# Altium schematic sheet LPEDT_revA1_bikeit_MCU_IO.SchDoc(LPEDT_revA1_bikeit_MCU_IO)
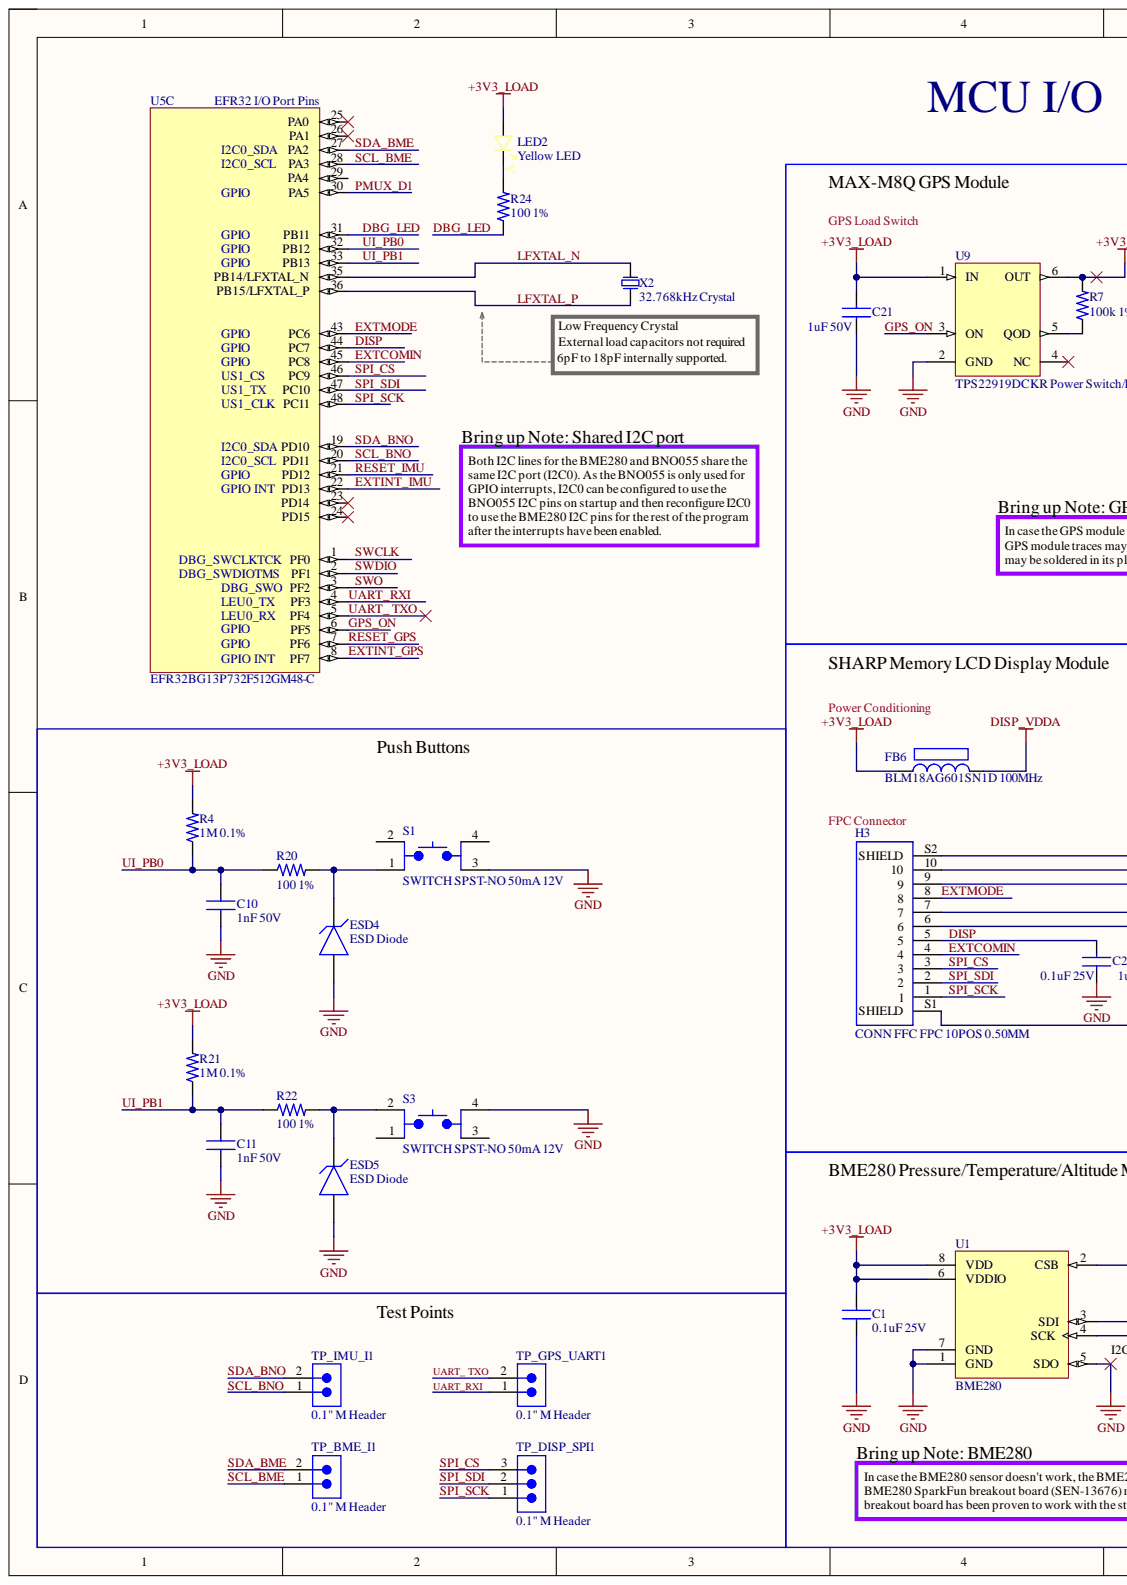
[diagram p0: LPEDT_revA1_bikeit_MCU_IO.SchDoc, left]
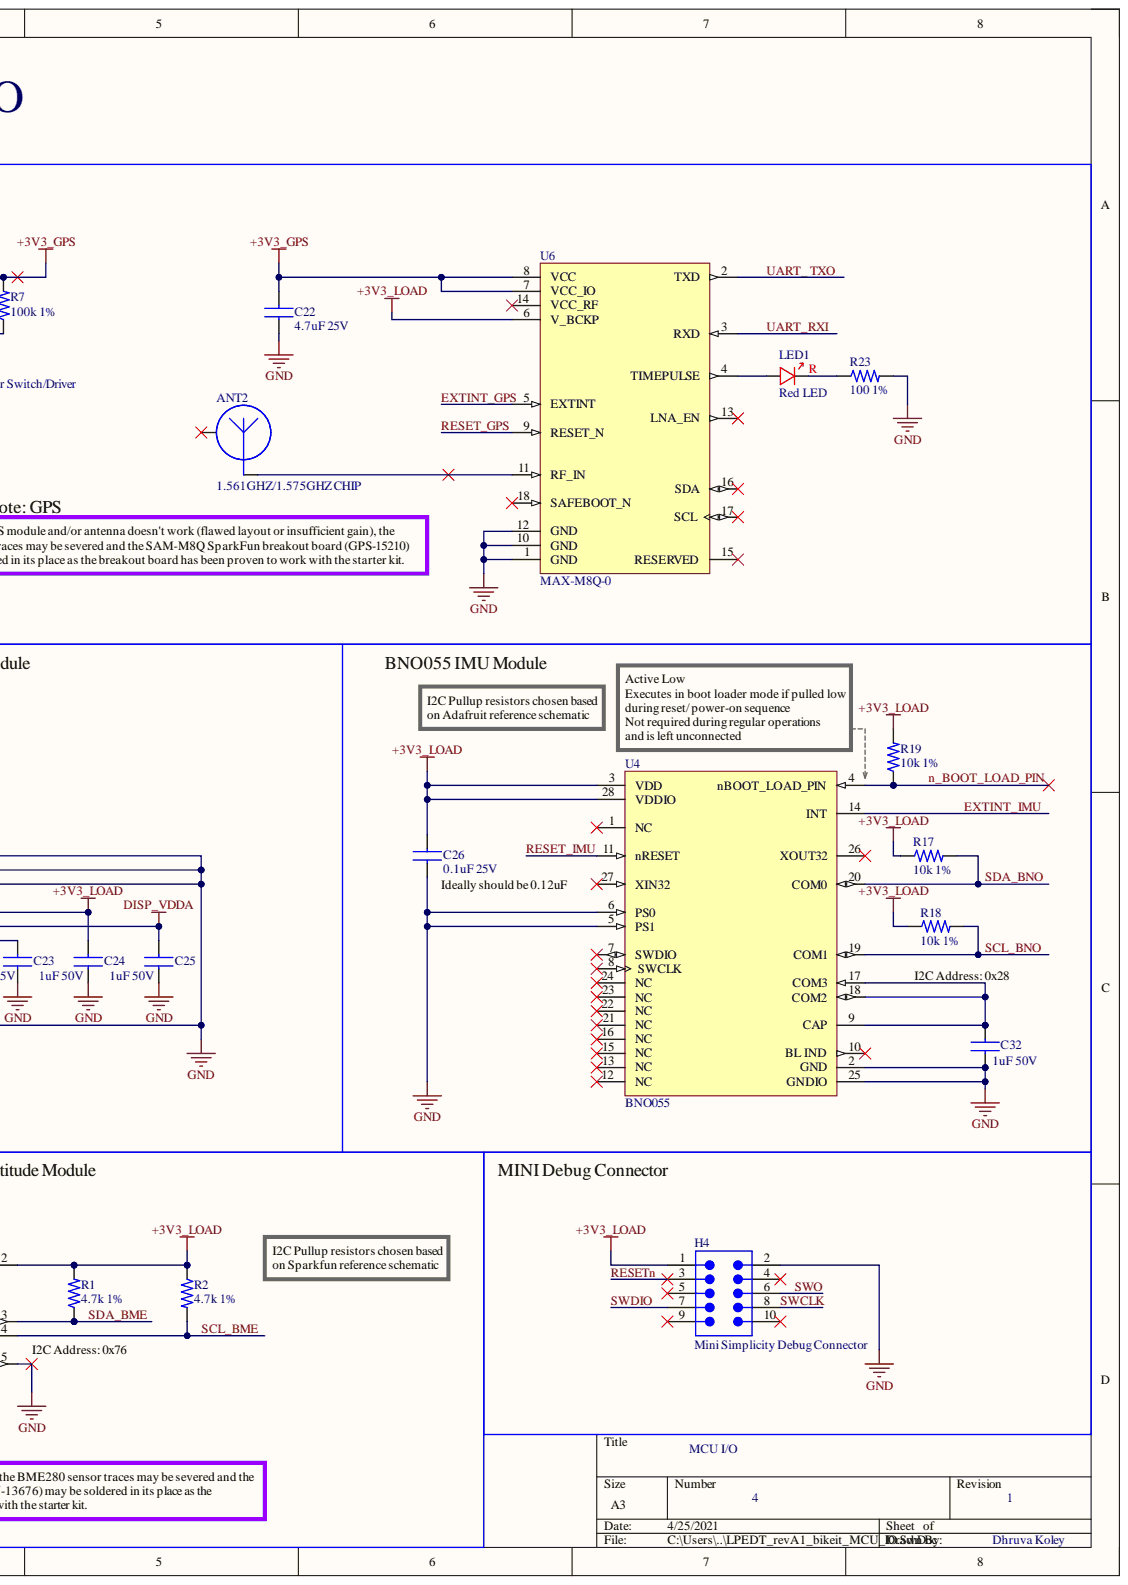
[diagram p1: LPEDT_revA1_bikeit_MCU_IO.SchDoc, right]

## Parts
ANT2  1.561GHZ/1.575GHZ CHIP  [CHIP_ANTENNA]
C1  0.1uF 25V  [CAP_0603_1.60mm*0.80mm]
C10  1nF 50V  Capacitance: 1nF  Voltage: 50V  [CAP_0603_1.60mm*0.80mm]
C11  1nF 50V  Capacitance: 1nF  Voltage: 50V  [CAP_0603_1.60mm*0.80mm]
C21  1uF 50V  Capacitance: 1uF  Voltage Rating: 50V  [CAP_0603_1.60mm*0.80mm]
C22  4.7uF 25V  Capacitance: 4.7uF  [CAP_0603_1.60mm*0.80mm]
C23  0.1uF 25V  Voltage Rating: 25V  [CAP_0603_1.60mm*0.80mm]
C24  1uF 50V  Capacitance: 1uF  Voltage Rating: 50V  [CAP_0603_1.60mm*0.80mm]
C25  1uF 50V  Voltage Rating: 50V  [CAP_0603_1.60mm*0.80mm]
C26  0.1uF 25V  [CAP_0603_1.60mm*0.80mm]
C32  1uF 50V  Capacitance: 1uF  Voltage Rating: 50V  [CAP_0603_1.60mm*0.80mm]
ESD4  ESD Diode  [ESD_SOD882]
ESD5  ESD Diode  [ESD_SOD882]
FB6  BLM18AG601SN1D 100MHz  Frequency: 100MHz  [FB_Chip2PinSM127P600-8N]
H3  CONN FFC FPC 10POS 0.50MM  [FPC_AYF531035A]
H4  Mini Simplicity Debug Connector  [FTSH-105-01-XXX-DV-K]
LED1  Red LED  Color: Red  [LED_0603_RED_WL-SMCW]
LED2  Yellow LED  Color: Yellow  [LED_0603_YLW_WL-SMCW]
R1  4.7k 1%  Resistance Tolerance: 1%  [RES_0603_1608X06]
R2  4.7k 1%  Resistance Tolerance: 1%  [RES_0603_1608X06]
R4  1M 0.1%  Resistance Tolerance: 0.1%  [RES_0603_1608X06]
R7  100k 1%  Resistance Tolerance: 1%  [RES_0603_1608X06]
R17  10k 1%  Resistance Tolerance: 1%  [RES_0603_1608X06]
R18  10k 1%  Resistance Tolerance: 1%  [RES_0603_1608X06]
R19  10k 1%  Resistance Tolerance: 1%  [RES_0603_1608X06]
R20  100 1%  Tolerance: 1%  [RES_0603_1608X06]
R21  1M 0.1%  Resistance Tolerance: 0.1%  [RES_0603_1608X06]
R22  100 1%  Tolerance: 1%  [RES_0603_1608X06]
R23  100 1%  Tolerance: 1%  [RES_0603_1608X06]
R24  100 1%  Tolerance: 1%  [RES_0603_1608X06]
S1  SWITCH SPST-NO 50mA 12V  [SW_TL3305AF260QG]
S3  SWITCH SPST-NO 50mA 12V  [SW_TL3305AF260QG]
TP_BME_I1  0.1" M Header  [2-PIN_MALE_NO 3D]
TP_DISP_SPI1  0.1" M Header  [3-PIN_MALE_NO 3D]
TP_GPS_UART1  0.1" M Header  [2-PIN_MALE_NO 3D]
TP_IMU_I1  0.1" M Header  [2-PIN_MALE_NO 3D]
U1  BME280  [BME280_PSON65P250X250X100-8N]
U4  BNO055  [BNO055-MFG]
U5  EFR32BG13P732F512GM48-C  [MCU_QFN50P700X700X90_HS-49N]  (part C)
U6  MAX-M8Q-0  [XCVR_MAX-M8C-0-10]
U9  TPS22919DCKR Power Switch/Driver  [LS_SOT65P210X110-6N]
X2  32.768kHz Crystal  [SMD_2 Crystal]

## Nets
+3V3_GPS: C22.1 R7.2 U6.7(VCC_IO) U6.8(VCC) U9.6(OUT)
+3V3_LOAD: C1.1 C21.1 C24.1 C26.1 FB6.1 H3.7 H4.1 LED2.A R1.2 R2.1 R4.2 R17.1 R18.1 R19.2 R21.2 U1.2(CSB) U1.6(VDDIO) U1.8(VDD) U4.3(VDD) U4.28(VDDIO) U6.6(V_BCKP) U9.1(IN)
DISP_VDDA: C25.1 FB6.2 H3.6
GND: C1.2 C10.2 C11.2 C21.2 C22.2 C23.2 C24.2 C25.2 C26.2 C32.2 ESD4.2 ESD5.2 H3.9 H3.10 H3.S1(SHIELD) H3.S2(SHIELD) H4.2 R23.2 S1.3 S3.4 U1.1(GND) U1.5(SDO) U1.7(GND) U4.2(GND) U4.5(PS1) U4.6(PS0) U4.25(GNDIO) U6.1(GND) U6.10(GND) U6.12(GND) U9.2(GND)
DBG_LED: R24.1 U5.31(PB11)
DISP: C23.1 H3.5 U5.44(PC7)
EXTCOMIN: H3.4 U5.45(PC8)
EXTINT_GPS: U5.8(PF7) U6.5(EXTINT)
EXTINT_IMU: U4.14(INT) U5.22(PD13)
EXTMODE: H3.8 U5.43(PC6)
GPS_ON: U5.6(PF5) U9.3(ON)
LFXTAL_N: U5.35(PB14/LFXTAL_N) X2.2
LFXTAL_P: U5.36(PB15/LFXTAL_P) X2.1
n_BOOT_LOAD_PIN: R19.1 U4.4(nBOOT_LOAD_PIN)
PMUX_D1: U5.30(PA5)
RESET_GPS: U5.7(PF6) U6.9(RESET_N)
RESET_IMU: U4.11(nRESET) U5.21(PD12)
RESETn: H4.3
SCL_BME: R2.2 TP_BME_I1.1 U1.4(SCK) U5.28(PA3)
SCL_BNO: R18.2 TP_IMU_I1.1 U4.19(COM1) U5.20(PD11)
SDA_BME: R1.1 TP_BME_I1.2 U1.3(SDI) U5.27(PA2)
SDA_BNO: R17.2 TP_IMU_I1.2 U4.20(COM0) U5.19(I2C0_SDA_PD10)
SPI_CS: H3.3 TP_DISP_SPI1.3 U5.46(PC9)
SPI_SCK: H3.1 TP_DISP_SPI1.1 U5.48(PC11)
SPI_SDI: H3.2 TP_DISP_SPI1.2 U5.47(PC10)
SWCLK: H4.8 U5.1(PF0)
SWDIO: H4.7 U5.2(PF1)
SWO: H4.6 U5.3(PF2)
UART_ TXO: TP_GPS_UART1.2 U5.5(PF4) U6.2(TXD)
UART_RXI: TP_GPS_UART1.1 U5.4(PF3) U6.3(RXD)
UI_PB0: C10.1 R4.1 R20.1 U5.32(PB12)
UI_PB1: C11.1 R21.1 R22.1 U5.33(PB13)
NetANT2_1: ANT2.1 U6.11(RF_IN)
NetC32_1: C32.1 U4.9(CAP) U4.17(COM3) U4.18(COM2)
NetESD4_1: ESD4.1 R20.2 S1.1
NetESD5_1: ESD5.1 R22.2 S3.2
NetLED1_A: LED1.A U6.4(TIMEPULSE)
NetLED1_C: LED1.C R23.1
NetLED2_C: LED2.C R24.2
NetR7_1: R7.1 U9.5(QOD)

Not connected: ANT2 2; H4 4 5 9 10; S1 2 4; S3 1 3; U4 1(NC) 7(SWDIO) 8(SWCLK) 10(BL_IND) 12(NC) 13(NC) 15(NC) 16(NC) 21(NC) 22(NC) 23(NC) 24(NC) 26(XOUT32) 27(XIN32); U5 23(PD14) 24(PD15) 25(PA0) 26(PA1) 29(PA4); U6 13(LNA_EN) 14(VCC_RF) 15(RESERVED) 16(SDA) 17(SCL) 18(SAFEBOOT_N); U9 4(NC)

## Library data of the parts
ANT2 = 1575AT43A0040E: RF ANT 1.561GHZ/1.575GHZ CHIP; Manufacturer: Johanson; Bandwidth: 40MHz; Gain: -2.5dB; Impedance: 50R; Max Power Dissipation: 3W; Number of Bands: 1; Return Loss: -9.5dB; Case/Package: SMD/SMT; Category: RF Antennas; Component Kind: Standard; ComponentLink1Description: https://datasheet.ciiva.com/2792/jti-antenna-1575at43a40-2006-09-2792227.pdf?src-supplier=Digi-Key; ComponentLink1URL: https://datasheet.ciiva.com/2792/jti-antenna-1575at43a40-2006-09-2792227.pdf?src-supplier=Digi-Key; ComponentLink2Description: https://datasheet.ciiva.com/24048/antenna-1575at43a0040-258086-24048619.pdf?src-supplier=Mouser; ComponentLink2URL: https://datasheet.ciiva.com/24048/antenna-1575at43a0040-258086-24048619.pdf?src-supplier=Mouser; ComponentLink3Description: https://datasheet.ciiva.com/4172/antenna-1575at43a0040-4172807.pdf?src-supplier=Arrow+Electronics; ComponentLink3URL: https://datasheet.ciiva.com/4172/antenna-1575at43a0040-4172807.pdf?src-supplier=Arrow+Electronics; Frequency: 1.575GHz; Height: 0.031inch; Lead Free: Lead Free; Length: 7mm; Manufacturer Part Number: 1575AT43A0040E; Max Operating Temperature: 85°C; Min Operating Temperature: -40°C; Mount: Surface Mount; Number of Pins: 2; Package Quantity: 1; Packaging: Tape and Reel; Power Rating: 3W; Pricing 1: 1=0.84000, 10=0.75200, 25=0.71400, 100=0.58650, 250=0.54824, 500=0.48450 (USD); RoHS: Yes; Stock 1: 56; Supplier 1: Digi-Key; Supplier Part Number 1: 712-1003-1-ND; Termination: Solder; Width: 2mm; Library Name: LPEDT_revA1_bikeit_IL.IntLib
C1 C23 C26 = GCJ188R71E104KA12D: CAP CER 0.1UF 25V X7R 0603; Manufacturer: Murata; Capacitance: 100nF; Tolerance: 10%; Case Code (Imperial): 0603; Case Code (Metric): 1608; Case/Package: 0603; Category: Ceramic Capacitors; Component Kind: Standard; ComponentLink1Description: http://search.murata.co.jp/Ceramy/image/img/A01X/G101/ENG/GCJ188R71E104KA12-01.pdf?src-supplier=Digi-Key; ComponentLink1URL: http://search.murata.co.jp/Ceramy/image/img/A01X/G101/ENG/GCJ188R71E104KA12-01.pdf?src-supplier=Digi-Key; Features: Soft Termination; Manufacturer Part Number: GCJ188R71E104KA12D; Max Operating Temperature: 125°C; Min Operating Temperature: -55°C; Mount: Surface Mount; Packaging: Tape and Reel; Pricing 1: 1=0.21000, 10=0.14900, 50=0.08480, 100=0.07030, 500=0.04928, 1000=0.04080 (USD); Ratings: AEC-Q200; RoHS: Yes; RoHS Compliant: Yes; Stock 1: 1672; Supplier 1: Mouser; Supplier Part Number 1: 81-GCJ188R71E104KA2D; Library Name: LPEDT_revA1_bikeit.SchLib
  C1 C26: Voltage Rating: 25V
C10 C11 = CL10B102KB8NNNC: Cap Ceramic 0.001uF 50V X7R 10% Pad SMD 0603 125°C T/R; Manufacturer: Samsung; Case Code (Imperial): 0603; Case Code (Metric): 1608; Case/Package: 0603; Category: Ceramic Capacitors; Component Kind: Standard; ComponentLink1Description: https://datasheet.ciiva.com/25805/cl10b102kb8nnnc-25805137.pdf?src-supplier=Digi-Key; ComponentLink1URL: https://datasheet.ciiva.com/25805/cl10b102kb8nnnc-25805137.pdf?src-supplier=Digi-Key; ComponentLink2Description: https://datasheet.ciiva.com/15216/getdatasheetpartid-359690-15216231.pdf?src-supplier=Verical; ComponentLink2URL: https://datasheet.ciiva.com/15216/getdatasheetpartid-359690-15216231.pdf?src-supplier=Verical; ComponentLink3Description: https://datasheet.ciiva.com/29198/458300-da-01-en-vs-kondensator-0603-29198498.pdf?src-supplier=Conrad; ComponentLink3URL: https://datasheet.ciiva.com/29198/458300-da-01-en-vs-kondensator-0603-29198498.pdf?src-supplier=Conrad; ComponentLink4Description: https://datasheet.ciiva.com/16199/cl05b104kq5nnnc-16199064.pdf?src-supplier=Future+Electronics; ComponentLink4URL: https://datasheet.ciiva.com/16199/cl05b104kq5nnnc-16199064.pdf?src-supplier=Future+Electronics; ComponentLink5Description: https://datasheet.ciiva.com/26310/mlcc-catalogdownload2013-eng-26310326.pdf?src-supplier=Distrelec; ComponentLink5URL: https://datasheet.ciiva.com/26310/mlcc-catalogdownload2013-eng-26310326.pdf?src-supplier=Distrelec; Construction: Flat; Contact Plating: Tin; Depth: 0.8mm; Dielectric: X7R; Height: 0.8mm; Lead Free: Lead Free; Length: 1.6mm; Manufacturer Part Number: CL10B102KB8NNNC; Material: Ceramic; Max Operating Temperature: 125°C; Min Operating Temperature: -55°C; Mount: Surface Mount; Package Quantity: 1; Packaging: Tape and Reel; Pricing 1: 4000=0.00643, 8000=0.00587 (USD); Radiation Hardening: No; RoHS: Yes; RoHS Compliant: Yes; Series: CL; Stock 1: 2467621; Supplier 1: Digi-Key; Supplier Part Number 1: 1276-1018-2-ND; Thickness: 0.035inch; Tolerance: 10%; Voltage Rating: 50V; Voltage Rating (DC): 50V; Width: 0.031inch; Library Name: LPEDT_revA1_bikeit_IL.IntLib
C21 C24 C25 C32 = GRT188R61H105KE13D: CAP, MLCC, AEC-Q200, X5R, 1uF, 50V, 0603; Manufacturer: Murata; Tolerance: 10%; Case Code (Imperial): 0603; Case Code (Metric): 1608; Case/Package: 0603; Category: Ceramic Capacitors; Component Kind: Standard; ComponentLink1Description: http://www.farnell.com/datasheets/2165959.pdf?src-supplier=Farnell; ComponentLink1URL: http://www.farnell.com/datasheets/2165959.pdf?src-supplier=Farnell; ComponentLink2Description: http://search.murata.co.jp/Ceramy/image/img/A01X/G101/ENG/GRT188R61H105KE13-01.pdf?src-supplier=Digi-Key; ComponentLink2URL: http://search.murata.co.jp/Ceramy/image/img/A01X/G101/ENG/GRT188R61H105KE13-01.pdf?src-supplier=Digi-Key; ComponentLink3Description: https://search.murata.co.jp/Ceramy/image/img/A01X/G101/ENG/GRT188R61H105KE13-01.pdf?src-supplier=Digi-Key; ComponentLink3URL: https://search.murata.co.jp/Ceramy/image/img/A01X/G101/ENG/GRT188R61H105KE13-01.pdf?src-supplier=Digi-Key; ComponentLink4Description: http://www.farnell.com/datasheets/2165959.pdf?src-supplier=Newark; ComponentLink4URL: http://www.farnell.com/datasheets/2165959.pdf?src-supplier=Newark; Dielectric: X5R; Length: 0.063inch; Manufacturer Part Number: GRT188R61H105KE13D; Max Operating Temperature: 85°C; Min Operating Temperature: -55°C; Mount: Surface Mount; Packaging: Tape and Reel; Pricing 1: 1=0.26000, 10=0.17900, 50=0.10720, 100=0.09060, 500=0.06198, 1000=0.05483 (USD); Ratings: AEC-Q200; RoHS: Yes; RoHS Compliant: Yes; Stock 1: 645268; Supplier 1: Digi-Key; Supplier Part Number 1: 490-12330-1-ND; Thickness: 0.035inch; Voltage Rating (DC): 50V; Width: 0.031inch; Library Name: LPEDT_revA1_bikeit_IL.IntLib
  C25: Capacitance: 1uF
C22 = GRM188R61E475KE11D: Cap Ceramic 0603 4.7 uF 25 V ±10 % Tolerance X5R SMT Multilayer Ceramic Capacitor; Manufacturer: Murata; Tolerance: 10%; Case Code (Imperial): 0603; Case Code (Metric): 1608; Case/Package: 0603; Category: Ceramic Capacitors; Component Kind: Standard; ComponentLink1Description: https://datasheet.ciiva.com/46444/1769893-46444982.pdf?src-supplier=Element14; ComponentLink1URL: https://datasheet.ciiva.com/46444/1769893-46444982.pdf?src-supplier=Element14; ComponentLink2Description: https://datasheet.ciiva.com/46444/1769893-46444983.pdf?src-supplier=Element14; ComponentLink2URL: https://datasheet.ciiva.com/46444/1769893-46444983.pdf?src-supplier=Element14; ComponentLink3Description: https://datasheet.ciiva.com/14891/partnumbering-e-01-14891103.pdf?src-supplier=Digi-Key; ComponentLink3URL: https://datasheet.ciiva.com/14891/partnumbering-e-01-14891103.pdf?src-supplier=Digi-Key; ComponentLink4Description: https://datasheet.ciiva.com/14891/partnumbering-e-01-14891102.pdf?src-supplier=Digi-Key; ComponentLink4URL: https://datasheet.ciiva.com/14891/partnumbering-e-01-14891102.pdf?src-supplier=Digi-Key; Depth: 0.8mm; Dielectric: X5R; Height: 0.8mm; Lead Free: Lead Free; Length: 1.6mm; Manufacturer Part Number: GRM188R61E475KE11D; Material: Ceramic; Max Operating Temperature: 85°C; Min Operating Temperature: -55°C; Mount: Surface Mount; Package Quantity: 4000; Packaging: Cut Tape; Pricing 1: 1=0.36000, 10=0.24700, 50=0.17280, 100=0.14820, 500=0.11112, 1000=0.09384 (USD); REACH SVHC: No SVHC; RoHS: Yes; RoHS Compliant: Yes; Series: GRM; Stock 1: 307528; Supplier 1: Digi-Key; Supplier Part Number 1: 490-7203-1-ND; Thickness: 0.031inch; Voltage: 25V; Voltage Rating: 25V; Voltage Rating (DC): 25V; Width: 0.031inch; Library Name: LPEDT_revA1_bikeit_IL.IntLib
ESD4 ESD5 = SP1003-01ETG: ESD Suppressor Diode TVS Uni-Dir 5V Automotive 2-Pin SOD-882 T/R; Manufacturer: Littelfuse; Capacitance: 30pF; Clamping Voltage: 12V; Diode Type: ZENER; Leakage Current: 100nA; Max Surge Current: 7A; Min Breakdown Voltage: 6V; Number of Channels: 1; Polarity: Bidirectional,Unidirectional; Power Line Protection: No; Test Current: 1mA; Breakdown Voltage: 5V; Category: TVS Diodes; Component Kind: Standard; ComponentLink1Description: https://datasheet.ciiva.com/4507/littelfuse-tvs-diode-array-spa-sp1003-221268-4507734.pdf?src-supplier=Mouser; ComponentLink1URL: https://datasheet.ciiva.com/4507/littelfuse-tvs-diode-array-spa-sp1003-221268-4507734.pdf?src-supplier=Mouser; ComponentLink2Description: https://datasheet.ciiva.com/16488/littelfuse-tvs-diode-array-spa-sp1003-16488471.pdf?src-supplier=Future+Electronics; ComponentLink2URL: https://datasheet.ciiva.com/16488/littelfuse-tvs-diode-array-spa-sp1003-16488471.pdf?src-supplier=Future+Electronics; ComponentLink3Description: https://datasheet.ciiva.com/330/littelfuse-tvs-diode-array-sp1003-datasheet-pdf-330594.pdf?src-supplier=Digi-Key; ComponentLink3URL: https://datasheet.ciiva.com/330/littelfuse-tvs-diode-array-sp1003-datasheet-pdf-330594.pdf?src-supplier=Digi-Key; ComponentLink4Description: https://datasheet.ciiva.com/330/littelfuse-tvs-diode-array-sp1003-datasheet-pdf-330595.pdf?src-supplier=Digi-Key; ComponentLink4URL: https://datasheet.ciiva.com/330/littelfuse-tvs-diode-array-sp1003-datasheet-pdf-330595.pdf?src-supplier=Digi-Key; ComponentLink5Description: https://datasheet.ciiva.com/330/littelfuse-tvs-diode-array-sp1003-datasheet-pdf-330596.pdf?src-supplier=Digi-Key; ComponentLink5URL: https://datasheet.ciiva.com/330/littelfuse-tvs-diode-array-sp1003-datasheet-pdf-330596.pdf?src-supplier=Digi-Key; ComponentLink6Description: https://datasheet.ciiva.com/1268/1854133-1268499.pdf?src-supplier=Element14; ComponentLink6URL: https://datasheet.ciiva.com/1268/1854133-1268499.pdf?src-supplier=Element14; Lead Free: Lead Free; Manufacturer Part Number: SP1003-01ETG; Max Operating Temperature: 85°C; Min Operating Temperature: -40°C; Mount: Surface Mount; Number of Elements: 1; Number of Pins: 2; Number of Unidirectional Channels: 1; Operating Supply Voltage: 5V; Package Quantity: 3000; Packaging: Tape and Reel; Peak Pulse Current: 7A; Pricing 1: 1=0.45000, 10=0.35100, 100=0.21040, 500=0.19478, 1000=0.13245, 2000=0.12193, 5000=0.11686 (USD); REACH SVHC: No SVHC; Resistor Type: Zener; Reverse Breakdown Voltage: 6V; Reverse Standoff Voltage: 5V; RoHS: Yes; RoHS Compliant: Yes; Series: Automotive, AEC-Q101, SP1003, SPA®; Stock 1: 9965; Supplier 1: Digi-Key; Supplier Part Number 1: F4088CT-ND; Termination: SMD/SMT; Library Name: LPEDT_revA1_bikeit_IL.IntLib
FB6 = BLM18AG601SN1D: Ferrite Bead, 0603 / 1608, 600 ohm, 500 mA, Série BLM18A, 0.38 ohm, ± 25%; Manufacturer: Murata; Current Rating: 500mA; DC Resistance (DCR): 380mR; Impedance: 600R; Material: Steel,Ferrite; Number of Circuits: 1; Shielding: Unshielded; Case Code (Imperial): 0603; Case Code (Metric): 1608; Case/Package: 0603; Category: Inductors; Component Kind: Standard; ComponentLink1Description: https://datasheet.ciiva.com/19460/1844766-19460213.pdf?src-supplier=Jameco; ComponentLink1URL: https://datasheet.ciiva.com/19460/1844766-19460213.pdf?src-supplier=Jameco; ComponentLink2Description: https://datasheet.ciiva.com/29347/442309-da-01-en-chip-drossel-0603-600r-500ma-1-6x0-8mm-29347900.pdf?src-supplier=Conrad; ComponentLink2URL: https://datasheet.ciiva.com/29347/442309-da-01-en-chip-drossel-0603-600r-500ma-1-6x0-8mm-29347900.pdf?src-supplier=Conrad; ComponentLink3Description: https://datasheet.ciiva.com/41449/001172228-da-01-en-drossel-0603-600r-1-6x0-8mm-4000st-41449517.pdf?src-supplier=Conrad; ComponentLink3URL: https://datasheet.ciiva.com/41449/001172228-da-01-en-drossel-0603-600r-1-6x0-8mm-4000st-41449517.pdf?src-supplier=Conrad; ComponentLink4Description: https://datasheet.ciiva.com/1012/l0110s0100blm18a-1012017.pdf?src-supplier=Digi-Key; ComponentLink4URL: https://datasheet.ciiva.com/1012/l0110s0100blm18a-1012017.pdf?src-supplier=Digi-Key; ComponentLink5Description: https://datasheet.ciiva.com/1012/l0110s0100blm18a-1012018.pdf?src-supplier=Digi-Key; ComponentLink5URL: https://datasheet.ciiva.com/1012/l0110s0100blm18a-1012018.pdf?src-supplier=Digi-Key; ComponentLink6Description: https://datasheet.ciiva.com/1012/l0110s0100blm18a-1012019.pdf?src-supplier=Digi-Key; ComponentLink6URL: https://datasheet.ciiva.com/1012/l0110s0100blm18a-1012019.pdf?src-supplier=Digi-Key; ComponentLink7Description: https://datasheet.ciiva.com/1466/1756147-1466933.pdf?src-supplier=Element14; ComponentLink7URL: https://datasheet.ciiva.com/1466/1756147-1466933.pdf?src-supplier=Element14; ComponentLink8Description: https://datasheet.ciiva.com/1466/1756147-1466934.pdf?src-supplier=Element14; ComponentLink8URL: https://datasheet.ciiva.com/1466/1756147-1466934.pdf?src-supplier=Element14; ComponentLink9Description: https://datasheet.ciiva.com/16210/l0110s0100blm18a-16210006.pdf?src-supplier=Future+Electronics; ComponentLink9URL: https://datasheet.ciiva.com/16210/l0110s0100blm18a-16210006.pdf?src-supplier=Future+Electronics; ComponentLink10Description: https://datasheet.ciiva.com/3081/l0110s0100blm18a-3081613.pdf?src-supplier=Avnet; ComponentLink10URL: https://datasheet.ciiva.com/3081/l0110s0100blm18a-3081613.pdf?src-supplier=Avnet; Core Material: Ferrite; DC Current: 500mA; Depth: 0.8mm; Height: 0.8mm; Lead Free: Lead Free; Length: 1.6mm; Manufacturer Part Number: BLM18AG601SN1D; Max Current Rating: 500mA; Max DC Current: 500mA; Max Operating Temperature: 125°C; Military Standard: Not; Min Operating Temperature: -55°C; Number of Lines: 1; Number of Pins: 2; Package Quantity: 1; Packaging: Tape and Reel; Pricing 1: 1=0.10000, 10=0.07200, 25=0.06560, 50=0.05900, 100=0.04320, 250=0.03144, 500=0.02882, 1000=0.02489 (USD); Radiation Hardening: No; REACH SVHC: No SVHC; RoHS: Yes; RoHS Compliant: Yes; Stock 1: 826617; Supplier 1: Digi-Key; Supplier Part Number 1: 490-1014-1-ND; Termination: SMD/SMT; Test Frequency: 100MHz; Tolerance: 25%; Weight: 7.1E-05oz; Width: 0.8mm; Library Name: LPEDT_revA1_bikeit_IL.IntLib
H3 = AYF531035A: 10 Position 0.5 mm Solder Right Angle FFC / FPC SMD Socket; Manufacturer: Panasonic; Actuator Material: Polymer,Liquid Crystal Polymer; Current Rating: 500mA; Number of Positions: 10; Pitch: 0.02inch; Voltage Rating: 50V; Category: FFC / FPC; Component Kind: Standard; ComponentLink1Description: https://www3.panasonic.biz/ac/e_download/control/connector/fpc-ffc/catalog/con_eng_y5bw.pdf?src-supplier=Digi-Key; ComponentLink1URL: https://www3.panasonic.biz/ac/e_download/control/connector/fpc-ffc/catalog/con_eng_y5bw.pdf?src-supplier=Digi-Key; ComponentLink2Description: http://www3.panasonic.biz/ac/e_download/control/connector/fpc-ffc/catalog/con_eng_y5bw.pdf?src-supplier=Digi-Key; ComponentLink2URL: http://www3.panasonic.biz/ac/e_download/control/connector/fpc-ffc/catalog/con_eng_y5bw.pdf?src-supplier=Digi-Key; Contact Material: Copper Alloy; Contact Plating: Gold; Flammability Rating: UL94 V-0; Housing Material: Polymer; Lead Free: Lead Free; Manufacturer Part Number: AYF531035A; Max Operating Temperature: 85°C; Min Operating Temperature: -55°C; Mount: Surface Mount; Package Quantity: 500; Packaging: Cut Strip; Pricing 1: 1=0.93000, 10=0.81600, 25=0.76800, 50=0.73600, 100=0.70400, 250=0.64000, 500=0.57600, 1000=0.51200, 2500=0.46400 (USD); RoHS: Yes; Stock 1: 2493; Supplier 1: Digi-Key; Supplier Part Number 1: 255-5667-ND; Termination: Solder; Library Name: LPEDT_revA1_bikeit_IL.IntLib
H4 = FTSH-105-01-LM-DV-K: Conn Unshrouded Header HDR 10 POS 1.27mm Solder ST SMD Tube; Manufacturer: Samtec; Gender: Male; Number of Contacts: 10; Category: Connectors; Component Kind: Standard; ComponentLink1Description: https://datasheet.ciiva.com/16689/ftsh-mt-16689356.pdf?src-supplier=Avnet+Europe; ComponentLink1URL: https://datasheet.ciiva.com/16689/ftsh-mt-16689356.pdf?src-supplier=Avnet+Europe; ComponentLink2Description: https://datasheet.ciiva.com/10245/ftsh-mt-10245149.pdf?src-supplier=Avnet; ComponentLink2URL: https://datasheet.ciiva.com/10245/ftsh-mt-10245149.pdf?src-supplier=Avnet; Contact Material: Bronze; Contact Plating: Gold; Depth: 3.43mm; Housing Color: Black; Housing Material: Polymer; Length: 6.35mm; Manufacturer Part Number: FTSH-105-01-LM-DV-K; Max Operating Temperature: 125°C; Min Operating Temperature: -55°C; Number of Rows: 2; Orientation: Straight; Packaging: Rail/Tube; Pitch: 1.27mm; Pricing 1: 1=2.93000, 10=2.81700, 100=2.34750, 500=1.99536, 1000=1.70192, 5000=1.52586 (USD); Radiation Hardening: No; RoHS: Yes; Stock 1: 2459; Supplier 1: Digi-Key; Supplier Part Number 1: SAM9441-ND; Termination: Solder; Library Name: LPEDT_revA1_bikeit_IL.IntLib
LED1 = 150060RS75000: WURTH ELEKTRONIK - 150060RS75000 - LED, Red, 625 nm, 2 V, 30 mA, 250 mcd RoHS Compliant: Yes; Manufacturer: Wurth Electronics; Dominant Wavelength: 625nm; Forward Current: 30mA; Forward Voltage: 2V; Lens Style: Clear,Rectangular; Luminous Intensity: 250mcd; Test Current: 20mA; Viewing Angle: 140°; Case Code (Imperial): 0603; Case Code (Metric): 1608; Case/Package: 0603; Category: LEDs; Component Kind: Standard; ComponentLink1Description: https://datasheet.ciiva.com/29563/150060rs75000-29563040.pdf?src-supplier=Digi-Key; ComponentLink1URL: https://datasheet.ciiva.com/29563/150060rs75000-29563040.pdf?src-supplier=Digi-Key; ComponentLink2Description: https://datasheet.ciiva.com/29279/759428-da-01-en-chipled-0603-w-kl-rot-150060rs75000-29279196.pdf?src-supplier=Conrad; ComponentLink2URL: https://datasheet.ciiva.com/29279/759428-da-01-en-chipled-0603-w-kl-rot-150060rs75000-29279196.pdf?src-supplier=Conrad; Height: 0.7mm; Length: 1.6mm; Manufacturer Part Number: 150060RS75000; Max Operating Temperature: 85°C; Min Operating Temperature: -40°C; Mount: Surface Mount; Package Quantity: 1; Packaging: Cut Tape; Pricing 1: 1=0.14000, 50=0.13300, 100=0.11400, 500=0.09990 (USD); REACH SVHC: Unknown; RoHS: Yes; RoHS Compliant: Yes; Stock 1: 173130; Supplier 1: Digi-Key; Supplier Part Number 1: 732-4978-1-ND; Wavelength: 625nm; Width: 0.8mm; Library Name: LPEDT_revA1_bikeit_IL.IntLib
LED2 = 150060YS75000: WURTH ELEKTRONIK - 150060YS75000 - LED, Yellow, SMD, 0603, 30 mA, 2 V, 590 nm; Manufacturer: Wurth Electronics; Dominant Wavelength: 590nm; Forward Current: 30mA; Forward Voltage: 2V; Lens Style: Clear,Rectangular; Luminous Intensity: 120mcd; Test Current: 20mA; Viewing Angle: 140°; Case Code (Imperial): 0603; Case Code (Metric): 1608; Case/Package: 0603; Category: LEDs; Component Kind: Standard; ComponentLink1Description: https://datasheet.ciiva.com/23843/150060ys75000-23843250.pdf?src-supplier=Newark; ComponentLink1URL: https://datasheet.ciiva.com/23843/150060ys75000-23843250.pdf?src-supplier=Newark; ComponentLink2Description: https://datasheet.ciiva.com/29279/759432-da-01-en-chipled-0603-w-kl-gelb-150060ys75000-29279206.pdf?src-supplier=Conrad; ComponentLink2URL: https://datasheet.ciiva.com/29279/759432-da-01-en-chipled-0603-w-kl-gelb-150060ys75000-29279206.pdf?src-supplier=Conrad; ComponentLink3Description: https://datasheet.ciiva.com/29563/150060ys75000-29563045.pdf?src-supplier=Digi-Key; ComponentLink3URL: https://datasheet.ciiva.com/29563/150060ys75000-29563045.pdf?src-supplier=Digi-Key; ComponentLink4Description: https://datasheet.ciiva.com/22656/1911348-22656951.pdf?src-supplier=Element14; ComponentLink4URL: https://datasheet.ciiva.com/22656/1911348-22656951.pdf?src-supplier=Element14; ComponentLink5Description: https://datasheet.ciiva.com/29563/150060ys75000-29563033.pdf?src-supplier=Digi-Key; ComponentLink5URL: https://datasheet.ciiva.com/29563/150060ys75000-29563033.pdf?src-supplier=Digi-Key; ComponentLink6Description: https://datasheet.ciiva.com/48430/150060ys75000-eng-tds-48430044.pdf?src-supplier=Distrelec; ComponentLink6URL: https://datasheet.ciiva.com/48430/150060ys75000-eng-tds-48430044.pdf?src-supplier=Distrelec; Height: 0.7mm; Length: 1.6mm; Manufacturer Part Number: 150060YS75000; Max Operating Temperature: 85°C; Min Operating Temperature: -40°C; Mount: Surface Mount; Package Quantity: 4000; Packaging: Tape and Reel; Pricing 1: 1=0.14000, 50=0.13300, 100=0.11400, 500=0.09990 (USD); REACH SVHC: Unknown; RoHS: Yes; RoHS Compliant: Yes; Stock 1: 44523; Supplier 1: Digi-Key; Supplier Part Number 1: 732-4981-1-ND; Wavelength: 590nm; Width: 0.8mm; Library Name: LPEDT_revA1_bikeit_IL.IntLib
R1 R2 = RC0603FR-074K7L: RES SMD 4.7k  1% 0603; Manufacturer: Yageo; Max Power Dissipation: 100mW; Resistance: 4.7kR; Temperature Coefficient: 100ppm/°C; Voltage Rating: 50V; Case Code (Imperial): 0603; Case Code (Metric): 1608; Case/Package: 0603; Category: Chip SMD Resistors; Component Kind: Standard; ComponentLink1Description: https://datasheet.ciiva.com/15189/getdatasheetpartid-422754-15189760.pdf?src-supplier=Verical; ComponentLink1URL: https://datasheet.ciiva.com/15189/getdatasheetpartid-422754-15189760.pdf?src-supplier=Verical; ComponentLink2Description: https://datasheet.ciiva.com/22747/443971-22747528.pdf?src-supplier=Element14; ComponentLink2URL: https://datasheet.ciiva.com/22747/443971-22747528.pdf?src-supplier=Element14; ComponentLink3Description: https://datasheet.ciiva.com/7660/38590-7660794.pdf?src-supplier=Element14; ComponentLink3URL: https://datasheet.ciiva.com/7660/38590-7660794.pdf?src-supplier=Element14; ComponentLink4Description: https://datasheet.ciiva.com/7746/1868787-7746365.pdf?src-supplier=Element14; ComponentLink4URL: https://datasheet.ciiva.com/7746/1868787-7746365.pdf?src-supplier=Element14; ComponentLink5Description: https://datasheet.ciiva.com/46440/1868787-46440909.pdf?src-supplier=Element14; ComponentLink5URL: https://datasheet.ciiva.com/46440/1868787-46440909.pdf?src-supplier=Element14; ComponentLink6Description: https://datasheet.ciiva.com/7746/1738695-7746366.pdf?src-supplier=Element14; ComponentLink6URL: https://datasheet.ciiva.com/7746/1738695-7746366.pdf?src-supplier=Element14; Construction: Rectangular; Depth: 0.8mm; Features: Moisture Resistant; Height: 0.45mm; Lead Free: Lead Free; Lead Pitch: 0.3mm; Length: 1.6mm; Manufacturer Part Number: RC0603FR-074K7L; Max Operating Temperature: 155°C; Military Standard: Not; Min Operating Temperature: -55°C; Number of Pins: 2; Number of Terminations: 2; Packaging: Tape and Reel; Power Rating: 100mW; Pricing 1: 1=0.10000, 10=0.02300, 100=0.00930, 1000=0.00417, 2500=0.00362 (USD); REACH SVHC: No SVHC; Resistor Type: Thick Film; RoHS: Yes; RoHS Compliant: Yes; Series: RC; Size Code: 0603; Stock 1: 3174335; Supplier 1: Digi-Key; Supplier Part Number 1: 311-4.70KHRCT-ND; Termination: SMD/SMT; Tolerance: 1%; Voltage Rating (DC): 50V; Width: 0.8mm; Library Name: LPEDT_revA1_bikeit_IL.IntLib
R4 R21 = CPF0603B1M0E1: Res Thin Film 0603 1M Ohm 0.1% 1/16W ±25ppm/°C Molded SMD SMD Paper T/R; Manufacturer: TE Connectivity Neohm; Max Power Dissipation: 63mW; Number of Resistors: 1; Resistance: 1MR; Voltage Rating: 50V; Case Code (Imperial): 0603; Case Code (Metric): 1608; Case/Package: 0603; Category: Chip SMD Resistors; Component Kind: Standard; ComponentLink1Description: https://datasheet.ciiva.com/12793/eng-ds-1773200-i-12793711.pdf?src-supplier=Mouser; ComponentLink1URL: https://datasheet.ciiva.com/12793/eng-ds-1773200-i-12793711.pdf?src-supplier=Mouser; ComponentLink2Description: https://datasheet.ciiva.com/22670/1723857-22670697.pdf?src-supplier=Element14; ComponentLink2URL: https://datasheet.ciiva.com/22670/1723857-22670697.pdf?src-supplier=Element14; ComponentLink3Description: https://datasheet.ciiva.com/46382/1723857-46382668.pdf?src-supplier=Element14; ComponentLink3URL: https://datasheet.ciiva.com/46382/1723857-46382668.pdf?src-supplier=Element14; ELV: Compliant; Height: 0.45mm; Lead Free: Lead Free; Length: 1.55mm; Manufacturer Part Number: CPF0603B1M0E1; Max Operating Temperature: 155°C; Min Operating Temperature: -55°C; Mount: Surface Mount; Number of Terminations: 2; Package Quantity: 1000; Packaging: Tape and Reel; Power Rating: 63mW; Pricing 1: 1=0.59000, 10=0.42100, 100=0.21890 (USD); REACH SVHC: No SVHC; Resistor Type: Thin Film; RoHS: Yes; RoHS Compliant: Yes; Series: CPF, Neohm; Size Code: 0603; Stock 1: 104463; Supplier 1: Digi-Key; Supplier Part Number 1: A102234CT-ND; Temperature Coefficient: 25ppm/°C; Termination: Solder; Tolerance: 0.1%; Voltage Rating (DC): 50V; Weight: 7.1E-05oz; Width: 0.8mm; Library Name: LPEDT_revA1_bikeit_IL.IntLib
R7 = RC0603FR-07100KL: RES SMD 100k  1% 1/10W 0603; Manufacturer: Yageo; Max Power Dissipation: 100mW; Resistance: 100kR; Temperature Coefficient: 100ppm/°C; Voltage Rating: 50V; Working Voltage: 75V; Case Code (Imperial): 0603; Case Code (Metric): 1608; Case/Package: 0603; Category: Chip SMD Resistors; Component Kind: Standard; ComponentLink1Description: https://datasheet.ciiva.com/11808/0900766b80f96399-11808107.pdf?src-supplier=RSComponents; ComponentLink1URL: https://datasheet.ciiva.com/11808/0900766b80f96399-11808107.pdf?src-supplier=RSComponents; ComponentLink2Description: https://datasheet.ciiva.com/46440/1868787-46440889.pdf?src-supplier=Element14; ComponentLink2URL: https://datasheet.ciiva.com/46440/1868787-46440889.pdf?src-supplier=Element14; ComponentLink3Description: https://datasheet.ciiva.com/7746/1738695-7746234.pdf?src-supplier=Element14; ComponentLink3URL: https://datasheet.ciiva.com/7746/1738695-7746234.pdf?src-supplier=Element14; ComponentLink4Description: https://datasheet.ciiva.com/7983/443971-7983593.pdf?src-supplier=Element14; ComponentLink4URL: https://datasheet.ciiva.com/7983/443971-7983593.pdf?src-supplier=Element14; ComponentLink5Description: https://datasheet.ciiva.com/7746/1738695-7746235.pdf?src-supplier=Element14; ComponentLink5URL: https://datasheet.ciiva.com/7746/1738695-7746235.pdf?src-supplier=Element14; ComponentLink6Description: https://datasheet.ciiva.com/22747/443971-22747516.pdf?src-supplier=Element14; ComponentLink6URL: https://datasheet.ciiva.com/22747/443971-22747516.pdf?src-supplier=Element14; Construction: Rectangular; Depth: 0.8mm; Features: Moisture Resistant; Height: 0.45mm; Lead Free: Lead Free; Length: 1.6mm; Manufacturer Part Number: RC0603FR-07100KL; Max Operating Temperature: 155°C; Military Standard: Not; Min Operating Temperature: -55°C; Number of Pins: 2; Number of Terminations: 2; Packaging: Tape and Reel; Power Rating: 100mW; Pricing 1: 1=0.10000, 10=0.02300, 100=0.00930, 1000=0.00417, 2500=0.00362 (USD); REACH SVHC: No SVHC; Resistor Type: Thick Film; RoHS: Yes; RoHS Compliant: Yes; Series: RC; Stock 1: 21765991; Supplier 1: Digi-Key; Supplier Part Number 1: 311-100KHRCT-ND; Technology: THICK FILM; Termination: SMD/SMT; Tolerance: 1%; Voltage Rating (DC): 50V; Width: 0.8mm; Library Name: LPEDT_revA1_bikeit_IL.IntLib
R17-R19 = RC0603FR-0710KL: RES SMD 10k 1% 1/10W 0603; Manufacturer: Yageo; Max Power Dissipation: 100mW; Resistance: 10kR; Temperature Coefficient: 100ppm/°C; Voltage Rating: 75V; Voltage Rating (DC): 50V; Case Code (Imperial): 0603; Case Code (Metric): 1608; Case/Package: 0603; Category: Chip SMD Resistors; Component Kind: Standard; ComponentLink1Description: https://datasheet.ciiva.com/7660/38590-7660789.pdf?src-supplier=Element14; ComponentLink1URL: https://datasheet.ciiva.com/7660/38590-7660789.pdf?src-supplier=Element14; ComponentLink2Description: https://datasheet.ciiva.com/15189/getdatasheetpartid-409289-15189591.pdf?src-supplier=Verical; ComponentLink2URL: https://datasheet.ciiva.com/15189/getdatasheetpartid-409289-15189591.pdf?src-supplier=Verical; ComponentLink3Description: https://datasheet.ciiva.com/46440/1868787-46440891.pdf?src-supplier=Element14; ComponentLink3URL: https://datasheet.ciiva.com/46440/1868787-46440891.pdf?src-supplier=Element14; ComponentLink4Description: https://datasheet.ciiva.com/7983/443971-7983596.pdf?src-supplier=Element14; ComponentLink4URL: https://datasheet.ciiva.com/7983/443971-7983596.pdf?src-supplier=Element14; ComponentLink5Description: https://datasheet.ciiva.com/7746/1738695-7746239.pdf?src-supplier=Element14; ComponentLink5URL: https://datasheet.ciiva.com/7746/1738695-7746239.pdf?src-supplier=Element14; ComponentLink6Description: https://datasheet.ciiva.com/22747/443971-22747519.pdf?src-supplier=Element14; ComponentLink6URL: https://datasheet.ciiva.com/22747/443971-22747519.pdf?src-supplier=Element14; Construction: Rectangular; Depth: 0.8mm; Features: Moisture Resistant; Height: 0.45mm; Lead Free: Lead Free; Lead Pitch: 0.3mm; Length: 1.6mm; Manufacturer Part Number: RC0603FR-0710KL; Max Operating Temperature: 155°C; Military Standard: Not; Min Operating Temperature: -55°C; Number of Pins: 2; Number of Terminations: 2; Packaging: Tape and Reel; Power Rating: 100mW; Pricing 1: 1=0.10000, 10=0.02300, 100=0.00930, 1000=0.00417, 2500=0.00362 (USD); REACH SVHC: Unknown; Resistor Type: Thick Film; RoHS: Yes; RoHS Compliant: Yes; Series: RC; Size Code: 0603; Stock 1: 20705079; Supplier 1: Digi-Key; Supplier Part Number 1: 311-10.0KHRCT-ND; Termination: SMD/SMT; Tolerance: 1%; Weight: 7.1E-05oz; Width: 0.8mm; Library Name: LPEDT_revA1_bikeit_IL.IntLib
R20 R22-R24 = RMCF0603FT100R: RES SMD 100 1%; Manufacturer: Stackpole Electronics; Max Power Dissipation: 100mW; Resistance: 100R; Temperature Coefficient: 100ppm/°C; Case Code (Imperial): 0603; Case Code (Metric): 1608; Case/Package: 0603; Category: Chip SMD Resistors; Component Kind: Standard; ComponentLink1Description: https://datasheet.ciiva.com/15215/getdatasheetpartid-360413-15215981.pdf?src-supplier=Verical; ComponentLink1URL: https://datasheet.ciiva.com/15215/getdatasheetpartid-360413-15215981.pdf?src-supplier=Verical; Construction: Rectangular; Contact Plating: Tin,Matte; Depth: 0.8mm; Features: Automotive AEC-Q200; Height: 0.022inch; Lead Free: Lead Free; Length: 1.55mm; Manufacturer Part Number: RMCF0603FT100R; Max Operating Temperature: 155°C; Military Standard: Not; Min Operating Temperature: -55°C; Mount: Surface Mount; Number of Terminations: 2; Packaging: Tape and Reel; Power Rating: 100mW; Pricing 1: 1=0.10000, 10=0.01600, 100=0.00650, 1000=0.00292, 2500=0.00253 (USD); Radiation Hardening: No; Resistor Type: Thick Film; RoHS: Yes; RoHS Compliant: Yes; Series: RMCF; Size Code: 0603; Stock 1: 1363320; Supplier 1: Digi-Key; Supplier Part Number 1: RMCF0603FT100RCT-ND; Width: 0.031inch; Library Name: LPEDT_revA1_bikeit_IL.IntLib
S1 S3 = TL3305AF260QG: SWITCH TACTILE SPST-NO 50MA 12V; Manufacturer: E-Switch; Actuator Type: Standard; Illumination Type: Non-Illuminated; Mechanical Life: 200000Cycles; Operating Force: 260gf; Switch Function: Off-Mom; Switch Travel: 0.2mm; Throw Configuration: SPST; Category: Tactile Switches; Component Kind: Standard; ComponentLink1Description: http://www.farnell.com/datasheets/2554191.pdf?src-supplier=Farnell; ComponentLink1URL: http://www.farnell.com/datasheets/2554191.pdf?src-supplier=Farnell; ComponentLink2Description: http://www.farnell.com/datasheets/2554191.pdf?src-supplier=Newark; ComponentLink2URL: http://www.farnell.com/datasheets/2554191.pdf?src-supplier=Newark; ComponentLink3Description: http://www.farnell.com/datasheets/2554191.pdf?src-supplier=Element14; ComponentLink3URL: http://www.farnell.com/datasheets/2554191.pdf?src-supplier=Element14; ComponentLink4Description: http://spec_sheets.e-switch.com/specs/P010471.pdf?src-supplier=Digi-Key; ComponentLink4URL: http://spec_sheets.e-switch.com/specs/P010471.pdf?src-supplier=Digi-Key; ComponentLink5Description: http://spec_sheets.e-switch.com/specs/P010471.pdf?src-supplier=Mouser; ComponentLink5URL: http://spec_sheets.e-switch.com/specs/P010471.pdf?src-supplier=Mouser; ComponentLink6Description: http://spec_sheets.e-switch.com/specs/P010471.pdf?src-supplier=NAC+Semi; ComponentLink6URL: http://spec_sheets.e-switch.com/specs/P010471.pdf?src-supplier=NAC+Semi; Lead Free: Lead Free; Manufacturer Part Number: TL3305AF260QG; Max Operating Temperature: 70°C; Min Operating Temperature: -20°C; Mount: Surface Mount; Packaging: Tape and Reel; Pricing 1: 1=0.18000, 10=0.17700, 25=0.16760, 100=0.15250, 250=0.14336, 500=0.13116, 1000=0.10980 (USD); RoHS: No; Stock 1: 70002; Supplier 1: Digi-Key; Supplier Part Number 1: EG5353CT-ND; Termination: Gull Wing; Library Name: LPEDT_revA1_bikeit_IL.IntLib
TP_BME_I1 TP_GPS_UART1 TP_IMU_I1 = PRPC002SAAN-RC_1: CONN HEADER VERT 2POS 2.54MM; Manufacturer: Sullins; Connector Type: Header, Unshrouded, Breakaway; Number of Positions: 2; Number of Positions Loaded: All; Online Catalog: 0.100" (2.54mm) Pitch Connector Headers; Other Names: S9510E-02  S9510E-02-ND; Pitch: 0.100" (2.54mm); Category: Connectors, Interconnects; Color: Black; Component Kind: Standard; ComponentLink1Description: https://media.digikey.com/PDF/Data%20Sheets/Sullins%20PDFs/xRxCzzzSxxN-RC_ST_11635-B.pdf?src-supplier=Digi-Key; ComponentLink1URL: https://media.digikey.com/PDF/Data%20Sheets/Sullins%20PDFs/xRxCzzzSxxN-RC_ST_11635-B.pdf?src-supplier=Digi-Key; Contact Finish: Gold; Contact Finish Thickness: Flash; Contact Mating Length: 0.230" (5.84mm); Contact Type: Male Pin; Family: Rectangular Connectors - Headers, Male Pins; Fastening Type: -; Features: -; Manufacturer Part Number: PRPC002SAAN-RC; Mounting Type: Through Hole; Number of Rows: 1; Packaging: Bulk; Pricing 1: 1=0.08, 10=0.073, 25=0.054, 50=0.0464, 100=0.0449, 250=0.0387, 500=0.0372 (USD); Row Spacing: -; Series: -; Standard Package: 1; Stock 1: 42739; Supplier 1: Digi-Key; Supplier Part Number 1: S1011EC-02-ND; Termination: Solder; Library Name: LPEDT_revA1_bikeit_IL.IntLib
TP_DISP_SPI1 = PRPC003SAAN-RC_1: CONN HEADER VERT 3POS 2.54MM; Manufacturer: Sullins; Connector Type: Header, Unshrouded, Breakaway; Number of Positions: 3; Number of Positions Loaded: All; Online Catalog: 0.100" (2.54mm) Pitch Connector Headers; Other Names: S9510E-03  S9510E-03-ND; Pitch: 0.100" (2.54mm); Category: Connectors, Interconnects; Color: Black; Component Kind: Standard; ComponentLink1Description: https://media.digikey.com/PDF/Data%20Sheets/Sullins%20PDFs/xRxCzzzSxxN-RC_ST_11635-B.pdf?src-supplier=Digi-Key; ComponentLink1URL: https://media.digikey.com/PDF/Data%20Sheets/Sullins%20PDFs/xRxCzzzSxxN-RC_ST_11635-B.pdf?src-supplier=Digi-Key; Contact Finish: Gold; Contact Finish Thickness: Flash; Contact Mating Length: 0.230" (5.84mm); Contact Type: Male Pin; Family: Rectangular Connectors - Headers, Male Pins; Fastening Type: -; Features: -; Manufacturer Part Number: PRPC003SAAN-RC; Mounting Type: Through Hole; Number of Rows: 1; Packaging: Bulk; Pricing 1: 1=0.12, 10=0.109, 25=0.0812, 50=0.0696, 100=0.0673, 250=0.058, 500=0.0557 (USD); Row Spacing: -; Series: -; Standard Package: 1; Stock 1: 19067; Supplier 1: Digi-Key; Supplier Part Number 1: S1011EC-03-ND; Termination: Solder; Library Name: LPEDT_revA1_bikeit_IL.IntLib
U1 = BME280: Humidity Temperature Sensor 0 ~ 100% RH I²C, SPI ±3% 1s Surface Mount; MF: Bosch; Availability: Unavailable; Component Kind: Standard; MP: BME280; Package: LGA-8 Bosch; Price: None; SnapEDA_Link: https://www.snapeda.com/parts/BME280/Bosch%20Sensortec/view-part/49769/?ref=snap; Supplier 1: Digi-Key; Supplier Part Number 1: 828-1063-1-ND; Library Name: LPEDT_revA1_bikeit_IL.IntLib
U4 = BNO055: IMU ACCEL/GYRO/MAG I2C 28LGA  CMP-2000-05075-4; Sensor Type: Accelerometer; Case/Package: LGA; Component Kind: Standard; Lead Free: Lead Free; Max Operating Temperature: 85°C; Min Operating Temperature: -40°C; Mount: Surface Mount; Output Type: I2C; Packaging: Tape and Reel; Library Name: LPEDT_revA1_bikeit_IL.IntLib
U5 = EFR32BG13P732F512GM48-C: BLUE GECKO QFN48 2.4 GHZ 512KB 6; Manufacturer: Silicon Labs; Category: RF Receivers, Transceivers; Component Kind: Standard; ComponentLink1Description: http://www.farnell.com/datasheets/2813683.pdf?src-supplier=Farnell; ComponentLink1URL: http://www.farnell.com/datasheets/2813683.pdf?src-supplier=Farnell; Manufacturer Part Number: EFR32BG13P732F512GM48-C; Pricing 1: 1=7.61000, 10=6.79200, 25=6.11320, 80=5.56988, 230=5.02643, 440=4.51023, 945=3.80380 (USD); RoHS: No; Supplier 1: Digi-Key; Supplier Part Number 1: 336-4109-ND; Library Name: LPEDT_revA1_bikeit_IL.IntLib
U6 = MAX-M8Q-0: MAX-M8 RF Receiver BeiDou, Galileo, GLONASS, GNSS, GPS 1.575GHz -167dBm; MF: U-Blox America; Availability: Warning; Check_prices: https://www.snapeda.com/parts/MAX-M8Q-0/U-Blox%20America%20Inc./view-part/563405/?ref=eda; Component Kind: Standard; MP: MAX-M8Q-0; Package: SMD-18 u-blox; Price: None; Purchase-URL: https://pricing.snapeda.com/search/part/MAX-M8Q-0/?ref=eda; SnapEDA_Link: https://www.snapeda.com/parts/MAX-M8Q-0/U-Blox%20America%20Inc./view-part/563405/?ref=snap; Supplier 1: Digi-Key; Supplier Part Number 1: 672-MAX-M8Q-0TR-ND; Library Name: LPEDT_revA1_bikeit.SchLib
U9 = TPS22919DCKR: 5.5-V, 1.5-A, 90-mΩ load switch with adj. output discharge 6-SC70 -40 to 105; Manufacturer: Texas Instruments; Component Kind: Standard; Manufacturer Part Number: TPS22919DCKR; Pricing 1: 1=0.39000, 10=0.29100, 25=0.26240, 100=0.14310, 250=0.13832, 500=0.12402, 1000=0.09540 (USD); RoHS: Yes; RoHS Compliant: Yes; Stock 1: 68518; Supplier 1: Digi-Key; Supplier Part Number 1: 296-TPS22919DCKRCT-ND; Library Name: LPEDT_revA1_bikeit_IL.IntLib
X2 = CM8V-T1A-32.768KHZ-12.5PF-20PPM-TB-QA: 32.768kHz ±20ppm Crystal 12.5pF 70 kOhms 2-SMD, No Lead; Manufacturer: Micro Crystal; Component Kind: Standard; Manufacturer Part Number: CM8V-T1A-32.768KHZ-12.5PF-20PPM-TB-QA; Pricing 1: 1=0.77000, 10=0.63800, 50=0.57400, 100=0.51030, 500=0.48478 (USD); RoHS: No; Stock 1: 2694; Supplier 1: Digi-Key; Supplier Part Number 1: 2195-CM8V-T1A-32.768KHZ-12.5PF-20PPM-TB-QACT-ND; Library Name: LPEDT_revA1_bikeit_IL.IntLib
